# Altium schematic sheet 02_Top_Level.SchDoc("02 Top Level")
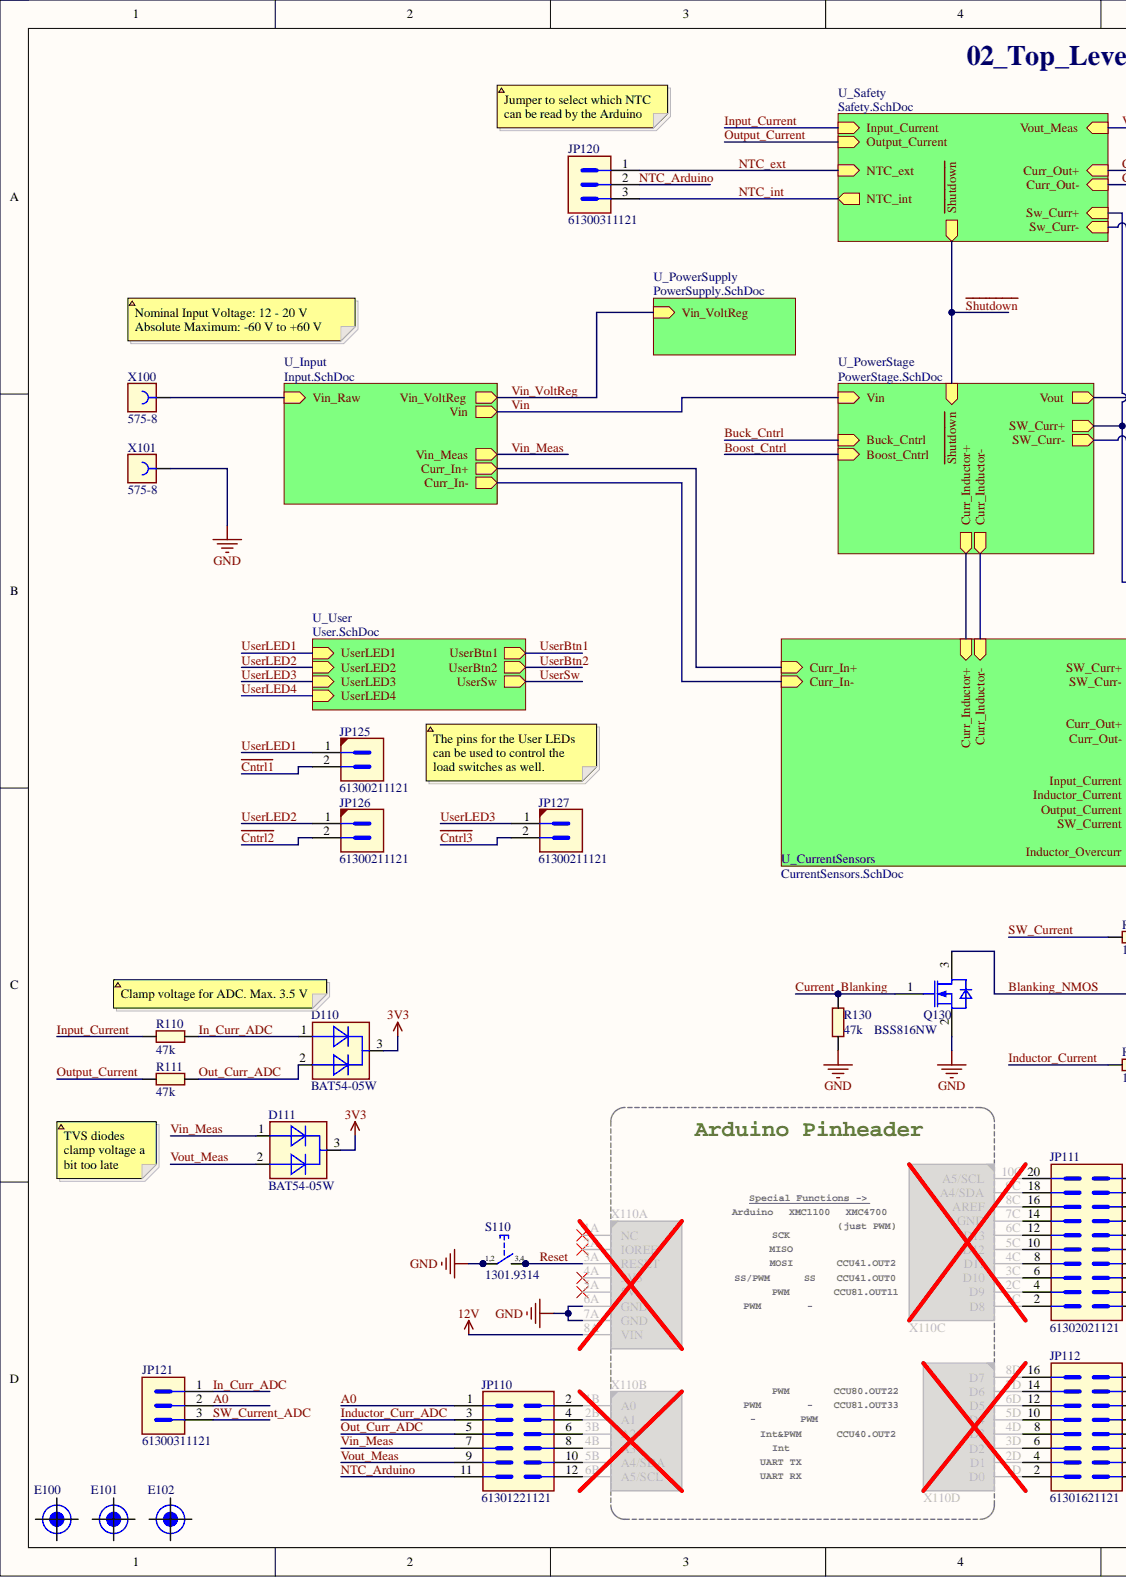
[diagram p0: 02_Top_Level.SchDoc, left]
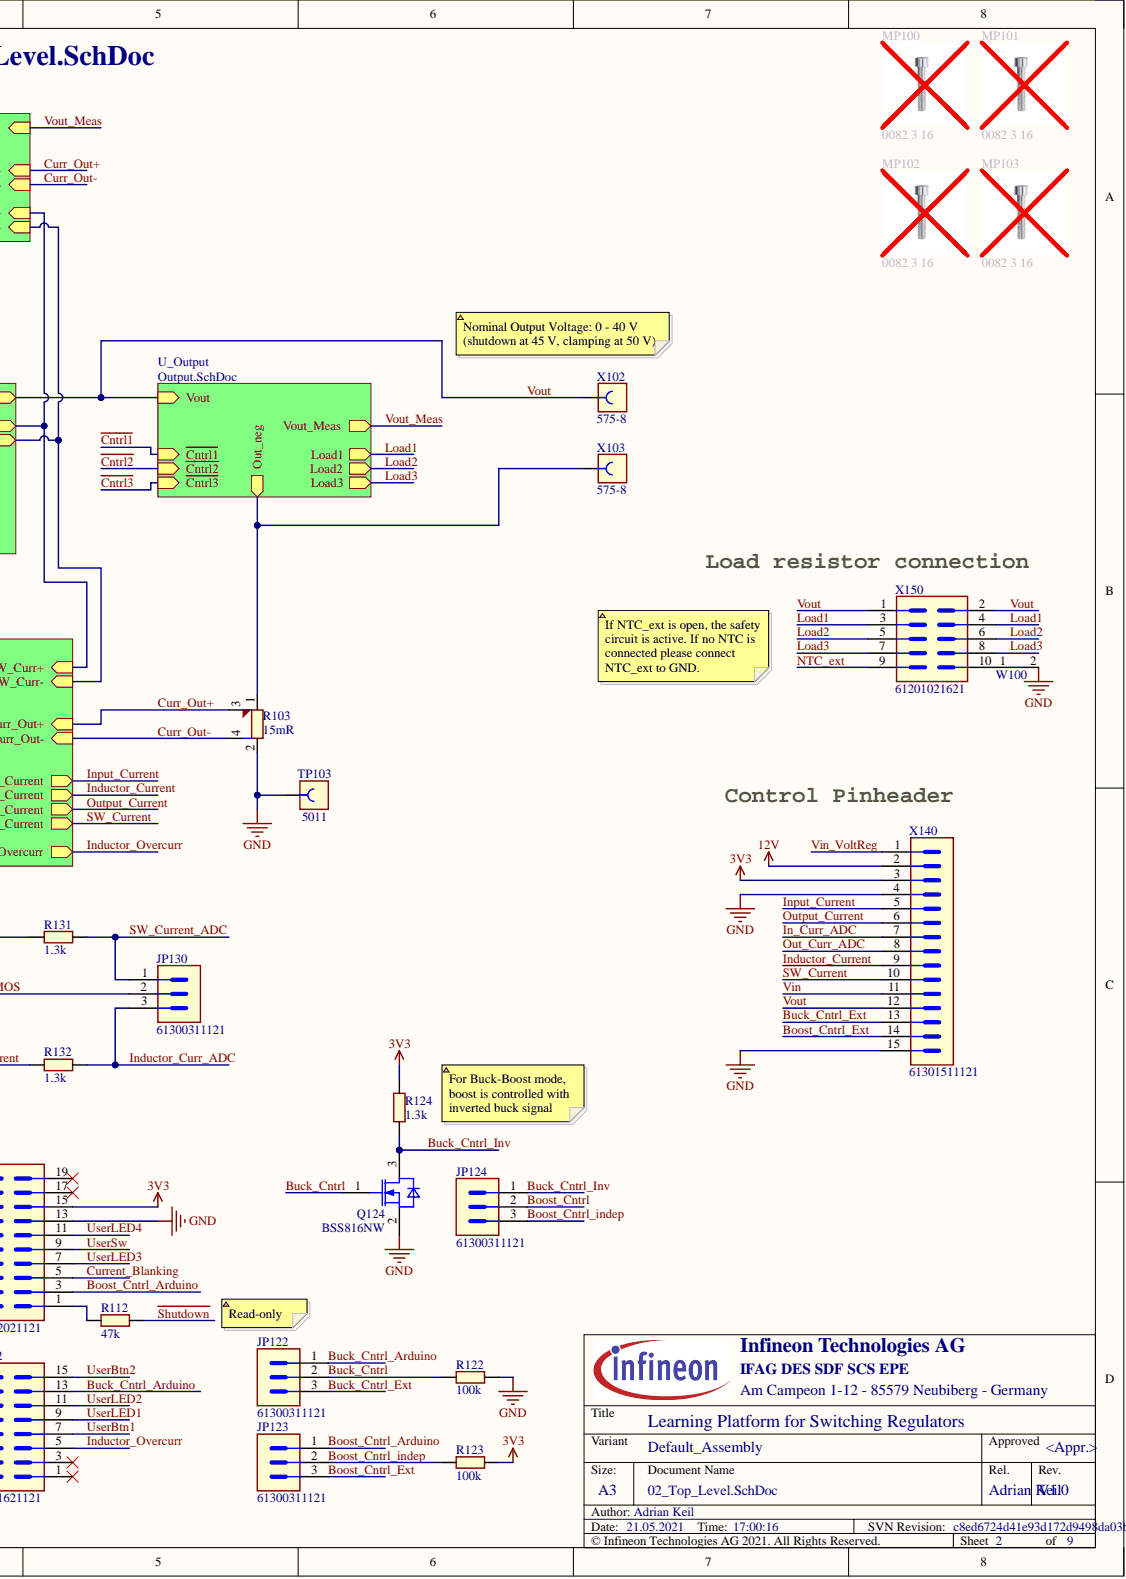
[diagram p1: 02_Top_Level.SchDoc, right]

## Parts
D110  BAT54-05W  [SOT65P210X100-3N-1-V]
D111  BAT54-05W  [SOT65P210X100-3N-1-V]
E100  (no value)  [fiducial]  (no BOM)
E101  (no value)  [fiducial]  (no BOM)
E102  (no value)  [fiducial]  (no BOM)
JP110  61301221121  [CON-M-THT-61301221121]
JP111  61302021121  [CON-M-THT-61302021121]
JP112  61301621121  [CON-M-THT-61301621121]
JP120  61300311121  [CON-M-THT-61300311121]
JP121  61300311121  [CON-M-THT-61300311121]
JP122  61300311121  [CON-M-THT-61300311121]
JP123  61300311121  [CON-M-THT-61300311121]
JP124  61300311121  [CON-M-THT-61300311121]
JP125  61300211121  [CON-M-THT-61300211121]
JP126  61300211121  [CON-M-THT-61300211121]
JP127  61300211121  [CON-M-THT-61300211121]
JP130  61300311121  [CON-M-THT-61300311121]
MP100  0082 3 16  [SCREW_0082 3 16_NPTH]
MP101  0082 3 16  [SCREW_0082 3 16_NPTH]
MP102  0082 3 16  [SCREW_0082 3 16_NPTH]
MP103  0082 3 16  [SCREW_0082 3 16_NPTH]
Q124  BSS816NW  [SOT65P210X100-3N-1-V]
Q130  BSS816NW  [SOT65P210X100-3N-1-V]
R103  15mR  [RES-SMD-L4T12]
R110  47k  [RESC1609X50N]
R111  47k  [RESC1609X50N]
R112  47k  [RESC1609X50N]
R122  100k  [RESC1609X50N]
R123  100k  [RESC1609X50N]
R124  1.3k  [RESC1609X50N]
R130  47k  [RESC1609X50N]
R131  1.3k  [RESC1609X50N]
R132  1.3k  [RESC1609X50N]
S110  1301.9314  [SW-SMD-1301.9314]
TP103  5011  [CON-THT-TP-5011]
W100  Net_Tie_2  [Net_Tie_Small_2P]  (value not drawn)
X100  575-8  [CON-F-TH-575-6]
X101  575-8  [CON-F-TH-575-6]
X102  575-8  [CON-F-TH-575-6]
X103  575-8  [CON-F-TH-575-6]
X110  Arduino-Uno  [Arduino-Uno]  (value not drawn)  (parts A,B,C,D)
X140  61301511121  [CON-M-THT-61301511121]
X150  61201021621  [CON-M-THT-61201021621]

## Nets
3V3: D110.3 D111.3 JP111.15 R123.1 R124.2 X140.3
12V: X110.8A(VIN) X140.2
GND: JP111.13 Q124.2 Q130.2 R103.2 R122.1 R130.1 S110.1 S110.2 TP103.1 W100.2 X101.1 X110.6A(GND) X110.7A(GND) X140.4 X140.15
~{Cntrl1}: JP125.2
~{Cntrl2}: JP126.2
~{Cntrl3}: JP127.2
~{Shutdown}: R112.1
A0: JP110.1 JP121.2
Blanking_NMOS: JP130.2 Q130.3
Boost_Cntrl: JP124.2
Boost_Cntrl_Arduino: JP111.3 JP123.1
Boost_Cntrl_Ext: JP123.3 X140.14
Boost_Cntrl_indep: JP123.2 JP124.3 R123.2
Buck_Cntrl: JP122.2 Q124.1 R122.2
Buck_Cntrl_Arduino: JP112.13 JP122.1
Buck_Cntrl_Ext: JP122.3 X140.13
Buck_Cntrl_Inv: JP124.1 Q124.3 R124.1
Curr_Out+: R103.3
Curr_Out-: R103.4
Current_Blanking: JP111.5 Q130.1 R130.2
In_Curr_ADC: D110.1 JP121.1 R110.1 X140.7
Inductor_Curr_ADC: JP110.3 JP130.3 R132.1
Inductor_Current: R132.2 X140.9
Inductor_Overcurr: JP112.5
Input_Current: R110.2 X140.5
Load1: X150.3 X150.4
Load2: X150.5 X150.6
Load3: X150.7 X150.8
NTC_Arduino: JP110.11 JP120.2
NTC_ext: JP120.1 X150.9
NTC_int: JP120.3
Out_Curr_ADC: D110.2 JP110.5 R111.1 X140.8
Output_Current: R111.2 X140.6
Reset: S110.3 S110.4 X110.3A(RESET)
SW_Current: R131.2 X140.10
SW_Current_ADC: JP121.3 JP130.1 R131.1
UserBtn1: JP112.7
UserBtn2: JP112.15
UserLED1: JP112.9 JP125.1
UserLED2: JP112.11 JP126.1
UserLED3: JP111.7 JP127.1
UserLED4: JP111.11
UserSw: JP111.9
Vin: X140.11
Vin_Meas: D111.1 JP110.7
Vin_VoltReg: X140.1
Vout: X102.1 X140.12 X150.1 X150.2
Vout_Meas: D111.2 JP110.9
NetJP110_2: JP110.2 X110.1B(A0)
NetJP110_4: JP110.4 X110.2B(A1)
NetJP110_6: JP110.6 X110.3B(A2)
NetJP110_8: JP110.8 X110.4B(A3)
NetJP110_10: JP110.10 X110.5B(A4/SDA)
NetJP110_12: JP110.12 X110.6B(A5/SCL)
NetJP111_1: JP111.1 R112.2
NetJP111_2: JP111.2 X110.1C(D8)
NetJP111_4: JP111.4 X110.2C(D9)
NetJP111_6: JP111.6 X110.3C(D10)
NetJP111_8: JP111.8 X110.4C(D11)
NetJP111_10: JP111.10 X110.5C(D12)
NetJP111_12: JP111.12 X110.6C(D13)
NetJP111_14: JP111.14 X110.7C(GND)
NetJP111_16: JP111.16 X110.8C(AREF)
NetJP111_17: JP111.17
NetJP111_18: JP111.18 X110.9C(A4/SDA)
NetJP111_19: JP111.19
NetJP111_20: JP111.20 X110.10C(A5/SCL)
NetJP112_1: JP112.1
NetJP112_2: JP112.2 X110.1D(D0)
NetJP112_3: JP112.3
NetJP112_4: JP112.4 X110.2D(D1)
NetJP112_6: JP112.6 X110.3D(D2)
NetJP112_8: JP112.8 X110.4D(D3)
NetJP112_10: JP112.10 X110.5D(D4)
NetJP112_12: JP112.12 X110.6D(D5)
NetJP112_14: JP112.14 X110.7D(D6)
NetJP112_16: JP112.16 X110.8D(D7)
NetR103_1: R103.1 X103.1
NetW100_1: W100.1 X150.10
NetX100_1: X100.1
NetX110_1A: X110.1A(NC)
NetX110_2A: X110.2A(IOREF)
NetX110_4A: X110.4A(3V3)
NetX110_5A: X110.5A(5V)
N00001:
N00002:
N00003:
N00004:
N00005:
N00006:

## Library data of the parts
D110 D111 = 592214: Silicon Schottky Diode, VR 30V; Manufacturer: Infineon Technologies; Automotive Qualified: AEC Q101; Combined Parameters: Vf:800mV;If:200mA;Ur:30V;Ir:2uA;Pmax:230mW; ComponentStatus: Released; Database Table Name: TPartsAll; PLMStatus: Active; QuickPick: Schottky Diode / PG-SOT323-3-1; Second Source allowed: Yes; Style: Single; Symbol type: Dual Diode Symbol 3 Pins, 1 Anode, 2 Anode, 3 Cathode; Version Number: V01; Voltage DC (max): na; Application: Protection; Article Number: 592214; Component Kind: Standard; ComponentLink1Description: Vendor WebSite; ComponentLink1URL: https://www.infineon.com/; ComponentLink2Description: Datasheet; ComponentLink2URL: http://webnetprod.muc.infineon.com/PCBPortal_Prod/WorkFlows/AltiumComponent/StandardDocumentation?Versionid=592215; Library Name: PCBLibraryData.SVNDbLib; Manufacturer Order Number: BAT54-05W; Package Description: SOT,0.65mm pitch;3 pin,1.25mm W X 2.00mm L X 1.00mm H body; PackageReference: PG-SOT323-3-1; Part Type: Diode; ROHS Compliant: Y; Supplier 1: Farnell; Supplier 2: DigiKey; Supplier 3: Mouser; Supplier Part Number 1: 2787840; Supplier Part Number 2: BAT5405WH6327XTSA1TR-ND; Temperature max: 150; Temperature min: 0; Temperature Range: Military; Type: Schottky Diode; Value: BAT54-05W
E100-E102 = 416637: Fiducial Mark; Legacy PartNumber: MEC10000008; Manufacturer: Infineon Technologies; Alternate View: No; Combined Parameters: - - -x- -; ComponentStatus: Released; Database Table Name: TPartsAll; Legacy DeviceID: MEC_Fiducial_Mark; PLMStatus: Active; Second Source allowed: Yes; Symbol Type: Fiducial Marker For PCB; Version Number: V01; Voltage DC (max): na; Article Number: 416637; ComponentLink1Description: Vendor WebSite; ComponentLink1URL: https://www.infineon.com/; ComponentLink2Description: Datasheet; ComponentLink2URL: http://webnetprod.muc.infineon.com/PCBPortal_Prod/WorkFlows/AltiumComponent/StandardDocumentation?Versionid=416638; Library Name: PCBLibraryData.SVNDbLib; Multible Parts: No; Part Type: Mechanical; Supplier 1: Farnell; Supplier 2: DigiKey; Supplier 3: Mouser; Temperature max: 0; Temperature min: 0; Temperature Range: Military
JP110 = 651705: WR-PHD Dual Pin Header, 2.54mm Pitch, Double row, 12 Pins; Manufacturer: Wurth Elektronik; Automotive Qualified: No; Combined Parameters: Board-to-Board Header (male);2x6;Mounting:THT;Orient:0° (vertical);Shielded:No; ComponentStatus: Released; Database Table Name: TPartsAll; Legacy DeviceID: WE_61301221121; PLMStatus: Active; QuickPick: 0° (vertical) / Board-to-Board; Second Source allowed: Yes; Style: Strip; Version Number: V00; Voltage DC (max): na; Article Number: 651705; Component Kind: Standard; ComponentLink1Description: Vendor WebSite; ComponentLink1URL: http://www.we-online.com; ComponentLink2Description: Datasheet; ComponentLink2URL: http://webnetprod.muc.infineon.com/PCBPortal_Prod/WorkFlows/AltiumComponent/StandardDocumentation?Versionid=651706; Library Name: PCBLibraryData.SVNDbLib; Manufacturer Order Number: 61301221121; Package Description: Connector, THT, 2.54 mm pitch, 12 pin, 5.08 mm L X 15.24 mm W X 8.89 mm H body; PackageReference: 2.54mm THT; Part Type: Connector; ROHS Compliant: Y; Supplier 1: Farnell; Supplier 2: DigiKey; Supplier 3: Mouser; Supplier Part Number 1: 2356134; Supplier Part Number 2: 732-5297-ND; Supplier Part Number 3: 710-61301221121; Temperature max: 105; Temperature min: -40; Temperature Range: Industrial; Type: Board-to-Board; Value: 61301221121
JP111 = 651707: WR-PHD Dual Pin Header, 2.54mm Pitch, Double row, 20 Pins; Manufacturer: Wurth Elektronik; Automotive Qualified: No; Combined Parameters: Board-to-Board Header (male);2x10;Mounting:THT;Orient:0° (vertical);Shielded:No; ComponentStatus: Released; Database Table Name: TPartsAll; Legacy DeviceID: WE_61302021121; PLMStatus: Active; QuickPick: 0° (vertical) / Board-to-Board; Second Source allowed: Yes; Style: Strip; Symbol Type: Header 10x2; Version Number: V00; Voltage DC (max): na; Article Number: 651707; Component Kind: Standard; ComponentLink1Description: Vendor WebSite; ComponentLink1URL: http://www.we-online.com; ComponentLink2Description: Datasheet; ComponentLink2URL: http://webnetprod.muc.infineon.com/PCBPortal_Prod/WorkFlows/AltiumComponent/StandardDocumentation?Versionid=651708; Library Name: PCBLibraryData.SVNDbLib; Manufacturer Order Number: 61302021121; Package Description: Connector, THT, 2.54 mm Pitch, 20 pin, 5.08 mm L X 25.40 mm W X 8.89 mm H body; PackageReference: 2.54mm THT; Part Type: Connector; ROHS Compliant: Y; Supplier 1: Farnell; Supplier 2: DigiKey; Supplier 3: Mouser; Supplier Part Number 1: 2356138; Supplier Part Number 2: 732-5301-ND; Supplier Part Number 3: 710-61302021121; Temperature max: 105; Temperature min: -40; Temperature Range: Industrial; Type: Board-to-Board; Value: 61302021121
JP112 = 638527: Connector Header Through Hole 16 position 0.100 (2.54mm); Manufacturer: Wurth Elektronik; Automotive Qualified: No; Combined Parameters: Board-to-Board Header (male);8x2;Mounting:THT;Orient:0° (vertical);Shielded:No; ComponentStatus: Released; Database Table Name: TPartsAll; Legacy DeviceID: WE_61301621121; PLMStatus: Active; QuickPick: 0° (vertical) / Board-to-Board; Second Source allowed: Yes; Style: Strip; Version Number: V01; Voltage DC (max): na; Article Number: 638527; Component Kind: Standard; ComponentLink1Description: Vendor WebSite; ComponentLink1URL: http://www.we-online.com; ComponentLink2Description: Datasheet; ComponentLink2URL: http://webnetprod.muc.infineon.com/PCBPortal_Prod/WorkFlows/AltiumComponent/StandardDocumentation?Versionid=638528; Library Name: PCBLibraryData.SVNDbLib; Manufacturer Order Number: 61301621121; Package Description: Connector, THT, 2.54 mm pitch, 16 pin, 5.08 mm L X 20.32 mm W X 8.89 mm H body; PackageReference: THT; Part Type: Connector; ROHS Compliant: Y; Supplier 1: Farnell; Supplier 2: DigiKey; Supplier 3: Mouser; Supplier Part Number 1: 2356136; Supplier Part Number 2: 732-5299-ND; Supplier Part Number 3: 710-61301621121; Temperature max: 105; Temperature min: -40; Temperature Range: Industrial; Type: Board-to-Board; Value: 61301621121
JP120-JP124 JP130 = 633993: WR-PHD Pin Header; Manufacturer: Wurth Elektronik; Automotive Qualified: No; Combined Parameters: Board-to-Cable Header (male);3x1;Mounting:THT;Orient:0° (vertical);Shielded:No; ComponentStatus: Released; Database Table Name: TPartsAll; Legacy DeviceID: WE_61300311121; PLMStatus: Active; QuickPick: 0° (vertical) / Board-to-Cable; Second Source allowed: Yes; Style: Strip; Version Number: V01; Voltage DC (max): na; Article Number: 633993; Component Kind: Standard; ComponentLink1Description: Vendor WebSite; ComponentLink1URL: http://www.we-online.com; ComponentLink2Description: Datasheet; ComponentLink2URL: http://webnetprod.muc.infineon.com/PCBPortal_Prod/WorkFlows/AltiumComponent/StandardDocumentation?Versionid=633994; Library Name: PCBLibraryData.SVNDbLib; Manufacturer Order Number: 61300311121; Package Description: Connector, THT; 2.54mm pitch, 7.62 mm L X 2.54 mm X 8.89 mm H body; PackageReference: THT; Part Type: Connector; ROHS Compliant: Y; Supplier 1: Farnell; Supplier 2: DigiKey; Supplier 3: Mouser; Supplier Part Number 1: 2356154; Supplier Part Number 2: 732-5316-ND; Supplier Part Number 3: 710-61300311121; Temperature max: 105; Temperature min: -40; Temperature Range: Industrial; Type: Board-to-Cable; Value: 61300311121
JP125-JP127 = 386760: Header, 2.54mm Pitch, 2 pin, Vertical, Single Row; Legacy PartNumber: CON01500943; Manufacturer: Wurth Elektronik; Alternat View: Yes; Automotive Qualified: No; Combined Parameters: Board-to-Board Header (male);2x1;Mounting:THT;Orient:0° (vertical);Shielded:No; ComponentStatus: Released; Database Table Name: TPartsAll; Legacy DeviceID: WE_61300211121; PLMStatus: Active; QuickPick: 0° (vertical) / Board-to-Board; Style: Strip; Version Number: V01; Voltage DC (max): na; Article Number: 386760; Component Kind: Standard; ComponentLink1Description: Vendor WebSite; ComponentLink1URL: http://www.we-online.com; ComponentLink2Description: Datasheet; ComponentLink2URL: http://webnetprod.muc.infineon.com/PCBPortal_Prod/WorkFlows/AltiumComponent/StandardDocumentation?Versionid=386761; Library Name: PCBLibraryData.SVNDbLib; Manufacturer Order Number: 61300211121; Package Description: Header, Vertical, 2.54mm Pitch; 2 Pins, Single row, 5.08mm L X 2.54mm W X 8.89mm H body; Part Type: Connector; ROHS Compliant: Y; Second Source allowed: Yes; Supplier 1: Farnell; Supplier 2: DigiKey; Supplier 3: Mouser; Supplier Part Number 1: 2356153; Supplier Part Number 2: 732-5315-ND; Supplier Part Number 3: 710-61300211121; Temperature max: 105; Temperature min: -40; Temperature Range: Industrial; Type: Board-to-Board; Value: 61300211121
MP100-MP103 = 455343: Cheese Head Screw With Hexagon Socket, DIN 912 (ISO 4762), M3, 16mm length; Legacy PartNumber: MEC10000167; Manufacturer: Wurth Elektronik; Alternate View: No; Combined Parameters: Steel Hexagonal 19x5.68 Screw; ComponentStatus: Released; Database Table Name: TPartsAll; Legacy DeviceID: MEC_0082 3 16; PLMStatus: Active; Second Source allowed: Yes; Style: Hexagonal; Symbol Type: Cheese-Head Screw, M2.5X5-DIN 912; Version Number: V01; Voltage DC (max): na; Article Number: 455343; Automotive Qualified: No; Component Kind: Standard; ComponentLink1Description: Vendor WebSite; ComponentLink1URL: http://www.we-online.com; ComponentLink2Description: Datasheet; ComponentLink2URL: http://webnetprod.muc.infineon.com/PCBPortal_Prod/WorkFlows/AltiumComponent/StandardDocumentation?Versionid=455344; Library Name: PCBLibraryData.SVNDbLib; Manufacturer Order Number: 0082 3 16; Multiple Parts: No; Package Description: M3 Cheese Head Screw X 5.68mm Head; PackageReference: THT; Part Type: Mechanical; QuickPick: Screw; ROHS Compliant: N; Supplier 1: Farnell; Supplier 2: DigiKey; Supplier 3: Mouser; Temperature max: 0; Temperature min: 0; Temperature Range: Military; Type: Screw; Value: 0082 3 16
Q124 Q130 = 644598: OptiMOS 2 Small-Signal-Transistor; Manufacturer: Infineon Technologies; Automotive Qualified: AEC Q101; Combined Parameters: N-Channel Enhancement;Uds:20V;Id:1.4A;Rds:240R;P:500mW; ComponentStatus: Released; Database Table Name: TPartsAll; Legacy DeviceID: IFX_BSS816NW; PLMStatus: Active; QuickPick: N-Channel Enhancement / 500mW / 1.4A / 20V / PG-SOT323-3-2; Second Source allowed: Yes; Style: Single; Symbol Type: Insulated-Gate Field-Effect Transistor (IGFET), N-Channel, Depletion,  Body Diode, Pin 1 Gate, 2 Source, 3 Drain, 3 Pins; Type: N-Channel Enhancement; Version Number: V01; Voltage DC (max): na; Application: Small Signal; Article Number: 644598; Component Kind: Standard; ComponentLink1Description: Vendor WebSite; ComponentLink1URL: https://www.infineon.com/; ComponentLink2Description: Datasheet; ComponentLink2URL: http://webnetprod.muc.infineon.com/PCBPortal_Prod/WorkFlows/AltiumComponent/StandardDocumentation?Versionid=644599; Library Name: PCBLibraryData.SVNDbLib; Manufacturer Order Number: BSS816NW; Package Description: SOT,0.65mm pitch;3 pin,1.25mm W X 2.00mm L X 1.00mm H body; PackageReference: PG-SOT323-3-2; Part Type: Transistor FET; ROHS Compliant: Y; Supplier 1: Farnell; Supplier 2: DigiKey; Supplier 3: Mouser; Supplier Part Number 1: 2617470; Supplier Part Number 2: BSS816NWH6327XTSA1CT-ND; Supplier Part Number 3: 726-SP000917562; Temperature max: 150; Temperature min: -55; Temperature Range: Military; Value: BSS816NW
R103 = 644055: RES / STD / 15mR / 500mW / 1% / 75ppm/K / -55°C to 155°C / 1206 (3216) / SMD / -; Manufacturer: Ohmite; Manufacturer Order Number: L4T12FR015FER; Alternate View: Yes; Automotive Qualified: No; Combined Parameters: TCR:75ppm/K;Tol.:-1%/+1%;Vdc:-;Idc:-;P:500mW; ComponentStatus: Released; Database Table Name: TPartsAll; PLMStatus: Active; QuickPick: 15mR / 1% / 0.5 / 1206 (3216); Style: 4-Terminal (Shunt); Symbol Type: Resistor; 4-Terminal (Shunt); Standard; 4 Pins; Version Number: V01; Application: Precision; Article Number: 644055; Component Kind: Standard; ComponentLink1Description: Vendor WebSite; ComponentLink1URL: http://www.ohmite.com; ComponentLink2Description: Datasheet; ComponentLink2URL: http://webnetprod.muc.infineon.com/PCBPortal_Prod/WorkFlows/AltiumComponent/StandardDocumentation?Versionid=644056; Library Name: PCBLibraryData.SVNDbLib; Multiple Parts: No; Package Description: Resistor, SMD, 4 pin, 3.00 mm L X 1.60 mm W X 0.65 mm H body; PackageReference: 1206 (3216); Part Type: Resistor; ROHS Compliant: Y; Second Source allowed: Yes; Supplier 1: Farnell; Supplier 2: DigiKey; Supplier 3: Mouser; Supplier Part Number 1: 3274221; Supplier Part Number 2: 273-L4T12FR015FERCT-ND; Supplier Part Number 3: 284-L4T12FR015FER; Technology: Thick Film; Temperature max: 155; Temperature min: -55; Temperature Range: Military; Tolerance Neg: 1%; Tolerance Pos: 1%; Type: Standard; Value: 15mR
R110-R112 R130 = 346552: RES / STD / 47k / 100mW / 1% / 100ppm/K / -55°C to 155°C / 0603 / SMD / -; Manufacturer: Vishay; Manufacturer Order Number: CRCW060347K0FK; Multiple Parts: No; Alternate View: Yes; Automotive Qualified: AEC Q200; Combined Parameters: TCR:100ppm/K;Tol.:-1%/+1%;Vdc:75V;Idc:-;P:100mW; ComponentStatus: Released; Database Table Name: TPartsAll; Legacy DeviceID: RES01801333; PLMStatus: Active; QuickPick: 47k / 1% / 0.1 / 0603; Style: Single; Symbol Type: Resistor; Single; Standard; 2 Pins; Version Number: V01; Voltage DC (max): 75V; Article Number: 346552; Component Kind: Standard; ComponentLink1Description: Vendor WebSite; ComponentLink1URL: http://www.vishay.com; ComponentLink2Description: Datasheet; ComponentLink2URL: http://webnetprod.muc.infineon.com/PCBPortal_Prod/WorkFlows/AltiumComponent/StandardDocumentation?Versionid=346553; Legacy PartNumber: RES01801333; Library Name: PCBLibraryData.SVNDbLib; PackageReference: 0603; Part Type: Resistor; ROHS Compliant: Y; Second Source allowed: Yes; Supplier 1: Farnell; Supplier 2: DigiKey; Supplier 3: Mouser; Supplier Part Number 2: 541-47.0KHCT-ND; Technology: Thick Film; Temperature max: 155; Temperature min: -55; Temperature Range: Military; Tolerance Neg: 1%; Tolerance Pos: 1%; Type: Standard; Value: 47k
R122 R123 = 346742: RES / STD / 100k / 100mW / 1% / 100ppm/K / -55°C to 155°C / 0603 / SMD / -; Manufacturer: Vishay; Manufacturer Order Number: CRCW0603100KFK; Multiple Parts: No; Alternate View: Yes; Automotive Qualified: AEC Q200; Combined Parameters: TCR:100ppm/K;Tol.:-1%/+1%;Vdc:75V;Idc:-;P:100mW; ComponentStatus: Released; Database Table Name: TPartsAll; Legacy DeviceID: RES01801371; PLMStatus: Active; QuickPick: 100k / 1% / 0.1 / 0603; Style: Single; Symbol Type: Resistor; Single; Standard; 2 Pins; Version Number: V01; Voltage DC (max): 75V; Article Number: 346742; Component Kind: Standard; ComponentLink1Description: Vendor WebSite; ComponentLink1URL: http://www.vishay.com; ComponentLink2Description: Datasheet; ComponentLink2URL: http://webnetprod.muc.infineon.com/PCBPortal_Prod/WorkFlows/AltiumComponent/StandardDocumentation?Versionid=346743; Legacy PartNumber: RES01801371; Library Name: PCBLibraryData.SVNDbLib; PackageReference: 0603; Part Type: Resistor; ROHS Compliant: Y; Second Source allowed: Yes; Supplier 1: Farnell; Supplier 2: DigiKey; Supplier 3: Mouser; Supplier Part Number 1: 1469649; Technology: Thick Film; Temperature max: 155; Temperature min: -55; Temperature Range: Military; Tolerance Neg: 1%; Tolerance Pos: 1%; Type: Standard; Value: 100k
R124 R131 R132 = 345662: RES / STD / 1.3k / 100mW / 1% / 100ppm/K / -55°C to 155°C / 0603 / SMD / -; Manufacturer: Vishay; Manufacturer Order Number: CRCW06031K30FK; Multiple Parts: No; Alternate View: Yes; Automotive Qualified: AEC Q200; Combined Parameters: TCR:100ppm/K;Tol.:-1%/+1%;Vdc:75V;Idc:-;P:100mW; ComponentStatus: Released; Database Table Name: TPartsAll; Legacy DeviceID: RES01801155; PLMStatus: Active; QuickPick: 1.3k / 1% / 0.1 / 0603; Style: Single; Symbol Type: Resistor; Single; Standard; 2 Pins; Version Number: V01; Voltage DC (max): 75V; Article Number: 345662; Component Kind: Standard; ComponentLink1Description: Vendor WebSite; ComponentLink1URL: http://www.vishay.com; ComponentLink2Description: Datasheet; ComponentLink2URL: http://webnetprod.muc.infineon.com/PCBPortal_Prod/WorkFlows/AltiumComponent/StandardDocumentation?Versionid=345663; Legacy PartNumber: RES01801155; Library Name: PCBLibraryData.SVNDbLib; PackageReference: 0603; Part Type: Resistor; ROHS Compliant: Y; Second Source allowed: Yes; Supplier 1: Farnell; Supplier 2: DigiKey; Supplier 3: Mouser; Technology: Thick Film; Temperature max: 155; Temperature min: -55; Temperature Range: Military; Tolerance Neg: 1%; Tolerance Pos: 1%; Type: Standard; Value: 1.3k
S110 = 380147: Pushbutton Tactile Switch, Single Pole Single Throw, 4 Pins; Legacy PartNumber: CON01500305; Manufacturer: Schurter; ComponentStatus: Released; Database Table Name: TPartsAll; Legacy DeviceID: SCH_1301.9314; PLMStatus: Active; Second Source allowed: Yes; Symbol type: Tactile Switch, Spring Return, Single Pole Single Throw - Normally Open, 4 Pins; Version Number: V01; Voltage DC (max): na; Article Number: 380147; Component Kind: Standard; ComponentLink1Description: Vendor WebSite; ComponentLink1URL: http://www.schurter.com.sg/; ComponentLink2Description: Datasheet; ComponentLink2URL: http://webnetprod.muc.infineon.com/PCBPortal_Prod/WorkFlows/AltiumComponent/StandardDocumentation?Versionid=380148; Library Name: PCBLibraryData.SVNDbLib; Manufacturer Order Number: 1301.9314; Package Description: SMD Switch, 6.00mm L X 6.00mm W X 4.3mm H body; Part Type: Electro Mechanic; ROHS Compliant: Y; Supplier 1: Farnell; Supplier 2: DigiKey; Supplier 3: Mouser; Supplier Part Number 1: 1217763; Supplier Part Number 2: 1301.9314-ND; Supplier Part Number 3: 693-1301.9314; Temperature max: 70; Temperature min: -20; Temperature Range: Commercial; Value: 1301.9314
TP103 = 382410: PC Test Point-Multipurpose THT, Black; Legacy PartNumber: CON01500530; Manufacturer: Keystone Electronics Corp.; Alternate View: Yes; Automotive Qualified: No; Combined Parameters: Board-to-Cable None (onboard);1x1;Mounting:THT;Orient:0° (vertical);Shielded:No; ComponentStatus: Released; Database Table Name: TPartsAll; Legacy DeviceID: KEY_5011; PLMStatus: Active; QuickPick: 0° (vertical) / Board-to-Cable; Style: Not Applicable; Symbol Type: Connector, 1 Lead, Female; Version Number: V01; Voltage DC (max): na; Application: Test; Article Number: 382410; Component Kind: Standard; ComponentLink1Description: Vendor WebSite; ComponentLink1URL: http://www.keyelco.com/; ComponentLink2Description: Datasheet; ComponentLink2URL: http://webnetprod.muc.infineon.com/PCBPortal_Prod/WorkFlows/AltiumComponent/StandardDocumentation?Versionid=382411; Library Name: PCBLibraryData.SVNDbLib; Manufacturer Order Number: 5011; Multiple Parts: No; Package Description: Test Point, 1.78 mm Lead Width, Round, 3.17 mm Dia X 8.28 mm H body; Part Type: Connector; ROHS Compliant: Y; Second Source allowed: Yes; Supplier 1: Farnell; Supplier 2: DigiKey; Supplier 3: Mouser; Supplier Part Number 1: 2431148; Supplier Part Number 2: 36-5011-ND; Supplier Part Number 3: 534-5011; Temperature max: 0; Temperature min: 0; Temperature Range: Military; Type: Board-to-Cable; Value: 5011
W100 = 453053: SMD Net Tie for two Nets; Legacy PartNumber: CON01501379; Manufacturer: Infineon Technologies; Manufacturer Order Number: Net Tie_small_2p; Alternate View: Yes; Combined Parameters: - onboard connector;-x-;Mounting:SMT;Orient:-;Shielded:-; ComponentStatus: Released; Database Table Name: TPartsAll; Legacy DeviceID: CON_Net Tie_small_2p; PLMStatus: Active; Value: Net Tie_small_2p; Version Number: V01; Voltage DC (max): na; Article Number: 453053; Component Kind: Net Tie; ComponentLink1Description: Vendor WebSite; ComponentLink1URL: https://www.infineon.com/; ComponentLink2Description: Datasheet; Library Name: PCBLibraryData.SVNDbLib; Package Description: SMD Net Tie 0.2mm x 0.6mm; PackageReference: SMD; Part Type: Connector; Second Source allowed: Yes; Supplier 1: Farnell; Supplier 2: DigiKey; Supplier 3: Mouser; Symbol Type: Net Tie for two Nets; Temperature max: 0; Temperature min: 0; Temperature Range: Military
X100-X103 = 599069: Non Insulated Jack, Brass; Manufacturer: Keystone Electronics Corp.; Alternate View: Yes; Automotive Qualified: No; Combined Parameters: Board-to-Cable Socket (female);-x-;Mounting:THT;Orient:0° (vertical);Shielded:No; ComponentStatus: Released; Database Table Name: TPartsAll; Legacy DeviceID: KEY_575-8; PLMStatus: Active; QuickPick: 0° (vertical) / Board-to-Cable; Style: Banana; Symbol Type: Connector, 1 Lead, Female; Version Number: V01; Voltage DC (max): na; Article Number: 599069; Component Kind: Standard; ComponentLink1Description: Vendor WebSite; ComponentLink1URL: http://www.keyelco.com/; ComponentLink2Description: Datasheet; ComponentLink2URL: http://webnetprod.muc.infineon.com/PCBPortal_Prod/WorkFlows/AltiumComponent/StandardDocumentation?Versionid=599070; Library Name: PCBLibraryData.SVNDbLib; Manufacturer Order Number: 575-8; Multiple Parts: No; Package Description: Terminal; 1 pin, 7.92 mm D X 2.51 mm H body; PackageReference: THT; Part Type: Connector; ROHS Compliant: N; Second Source allowed: Yes; Supplier 1: Farnell; Supplier 2: DigiKey; Supplier 3: Mouser; Supplier Part Number 2: 36-575-8-ND; Supplier Part Number 3: 534-575-8; Temperature max: 0; Temperature min: 0; Temperature Range: Military; Type: Board-to-Cable; Value: 575-8
X110 = 644888: Arduino Uno is a microcontroller board based on the ATmega328P; Manufacturer: Infineon Technologies; Alternate View: Yes; Automotive Qualified: No; Combined Parameters: Board-to-Board Socket (female);-x-;Mounting:THT;Orient:0° (vertical);Shielded:-; ComponentStatus: Released; Database Table Name: TPartsAll; Legacy DeviceID: Infineon_Arduino-Uno; PLMStatus: Active; QuickPick: 0° (vertical) / Board-to-Board; Version Number: V01; Voltage DC (max): na; Article Number: 644888; Component Kind: Standard; ComponentLink1Description: Vendor WebSite; ComponentLink1URL: https://www.infineon.com/; ComponentLink2Description: Datasheet; Library Name: PCBLibraryData.SVNDbLib; Manufacturer Order Number: Arduino-Uno; Multiple Parts: Yes; Package Description: Arduino-Uno, THT, 32 Pin, 68.58 mm L X 53.34 mm W X 8.64 mm H body; PackageReference: THT; Part Type: Connector; ROHS Compliant: N; Second Source allowed: Yes; Supplier 1: Farnell; Supplier 2: DigiKey; Supplier 3: Mouser; Symbol Type: Arduino Uno is a microcontroller board based on the ATmega328P; Temperature max: 85; Temperature min: -40; Temperature Range: Industrial; Type: Board-to-Board; Value: Arduino-Uno
X140 = 651701: WR-PHD Pin Header, 2.54mm Pitch, Single row, 15 Pins; Manufacturer: Wurth Elektronik; Alternate View: No; Combined Parameters: Board-to-Board Header (male);1x15;Mounting:THT;Orient:0° (vertical);Shielded:No; ComponentStatus: Released; Database Table Name: TPartsAll; Legacy DeviceID: WE_61301511121; PLMStatus: Active; QuickPick: 0° (vertical) / Board-to-Board; Second Source allowed: Yes; Style: Strip; Symbol Type: Header 15 Pins; Version Number: V00; Voltage DC (max): na; Article Number: 651701; Automotive Qualified: No; Component Kind: Standard; ComponentLink1Description: Vendor WebSite; ComponentLink1URL: http://www.we-online.com; ComponentLink2Description: Datasheet; ComponentLink2URL: http://webnetprod.muc.infineon.com/PCBPortal_Prod/WorkFlows/AltiumComponent/StandardDocumentation?Versionid=651702; Library Name: PCBLibraryData.SVNDbLib; Manufacturer Order Number: 61301511121; Multiple Parts: No; Package Description: Connector, THT, 2.54 mm Pitch, 15 pin, 2.54 mm L X 38.10 mm W X 8.89 mm H body; PackageReference: 2.54mm THT; Part Type: Connector; ROHS Compliant: Y; Supplier 1: Farnell; Supplier 2: DigiKey; Supplier 3: Mouser; Supplier Part Number 1: 2356165; Supplier Part Number 2: 732-5326-ND; Supplier Part Number 3: 710-61301511121; Temperature max: 105; Temperature min: -40; Temperature Range: Industrial; Type: Board-to-Board; Value: 61301511121
X150 = 638630: WR-BHD Male Box Header, 2.54mm pitch, 10 pin, Vertical, Double row; Manufacturer: Wurth Elektronik; Automotive Qualified: No; Combined Parameters: Board-to-Board/Cable Header (male);5x2;Mounting:THT;Orient:0° (vertical);Shielded:No; ComponentStatus: Released; Database Table Name: TPartsAll; Legacy DeviceID: WE_61201021621; PLMStatus: Active; QuickPick: 0° (vertical) / Board-to-Board/Cable; Second Source allowed: Yes; Style: Strip; Version Number: V01; Voltage DC (max): na; Article Number: 638630; Component Kind: Standard; ComponentLink1Description: Vendor WebSite; ComponentLink1URL: http://www.we-online.com; ComponentLink2Description: Datasheet; ComponentLink2URL: http://webnetprod.muc.infineon.com/PCBPortal_Prod/WorkFlows/AltiumComponent/StandardDocumentation?Versionid=638631; Library Name: PCBLibraryData.SVNDbLib; Manufacturer Order Number: 61201021621; Package Description: Connector, THT, 2.54mm Pitch; 10 Pins, 9.10 mm L X 20.36 mm W X 9.25 mm H body; PackageReference: THT; Part Type: Connector; ROHS Compliant: Y; Supplier 1: Farnell; Supplier 2: DigiKey; Supplier 3: Mouser; Supplier Part Number 1: 1642019; Supplier Part Number 2: 732-2094-ND; Supplier Part Number 3: 710-61201021621; Temperature max: 105; Temperature min: -40; Temperature Range: Industrial; Type: Board-to-Board/Cable; Value: 61201021621
